# Altium schematic sheet SIMCARD.SchDoc(SIMCARD)
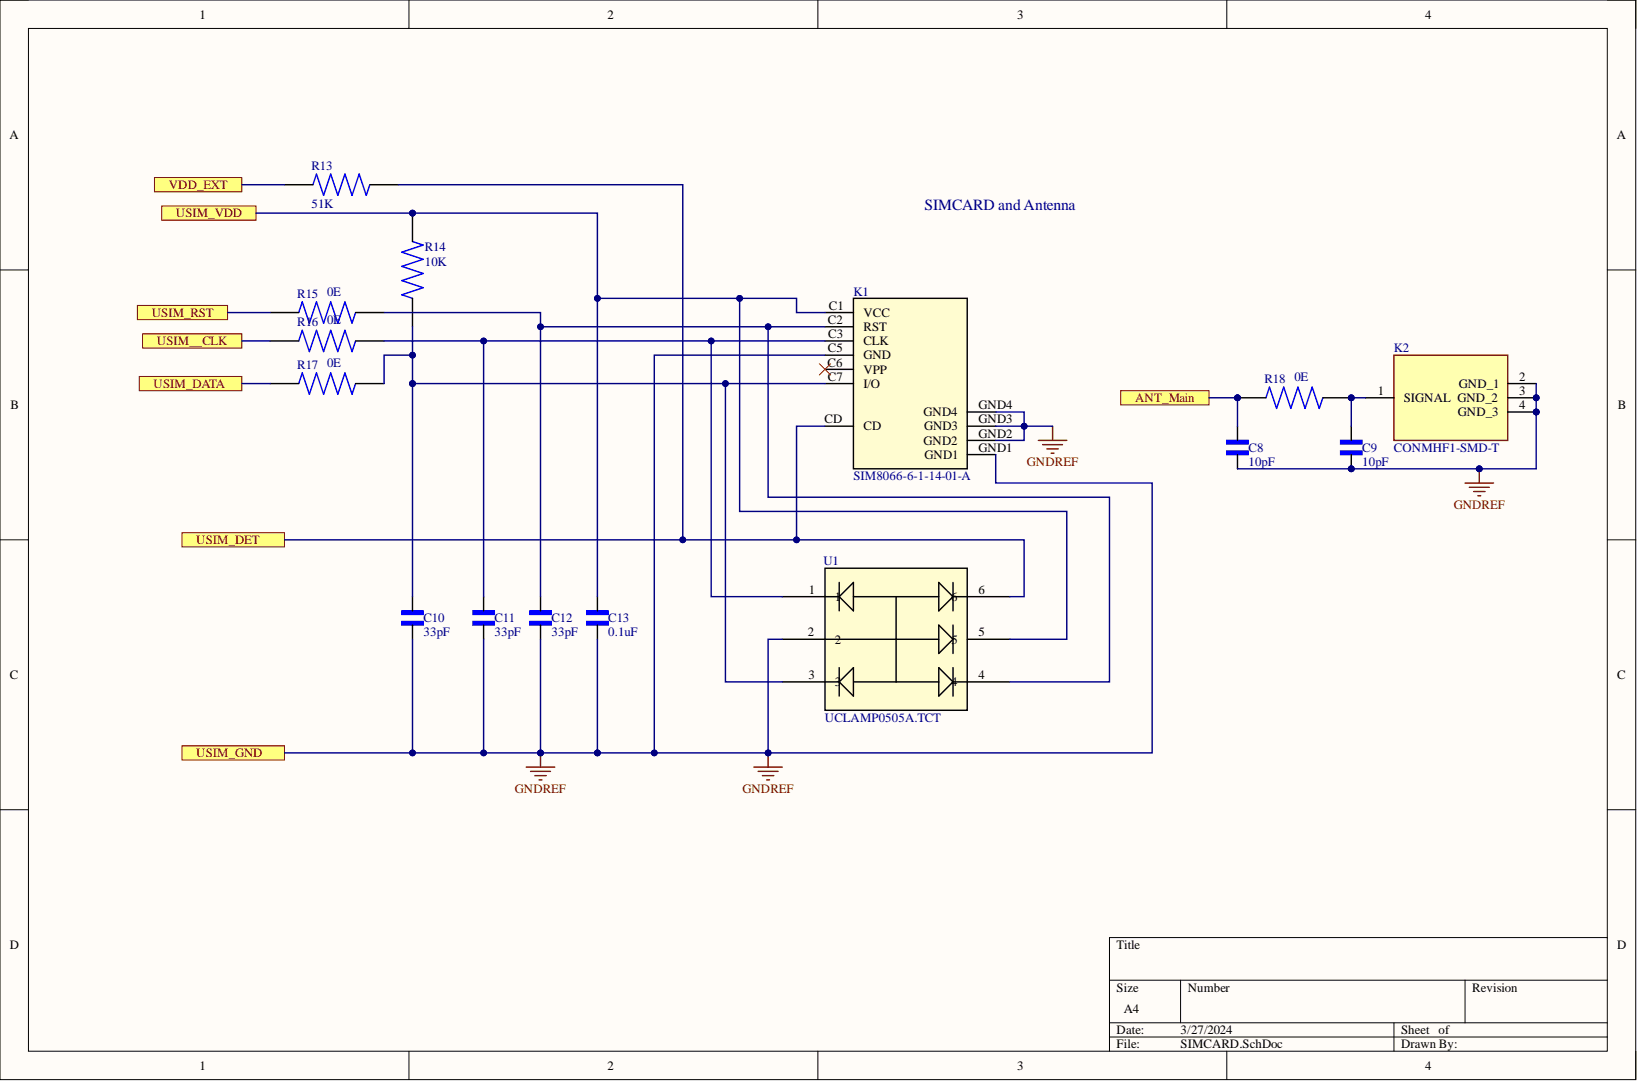
[diagram p0: SIMCARD.SchDoc]

## Parts
C8  10pF
C9  10pF
C10  33pF
C11  33pF
C12  33pF
C13  0.1uF
K1  SIM8066-6-1-14-01-A
K2  CONMHF1-SMD-T
R13  51K
R14  10K
R15  0E
R16  0E
R17  0E
R18  0E
U1  UCLAMP0505A.TCT

## Ports
ANT_Main, USIM__CLK, USIM_DATA, USIM_DET, USIM_GND, USIM_RST, USIM_VDD, VDD_EXT

## Nets
ANT_Main: C8.1 R18.1 ; port ANT_Main
GNDREF: C8.2 C9.2 C10.1 C11.1 C12.1 C13.1 K1.C5(GND) K1.GND1 K1.GND2 K1.GND3 K1.GND4 K2.2(GND_1) K2.3(GND_2) K2.4(GND_3) U1.2 ; port USIM_GND
USIM__CLK: R16.1 ; port USIM__CLK
USIM_DATA: R17.1 ; port USIM_DATA
USIM_DET: K1.CD R13.2 U1.6 ; port USIM_DET
USIM_RST: R15.1 ; port USIM_RST
USIM_VDD: C13.2 K1.C1(VCC) R14.2 U1.5 ; port USIM_VDD
VDD_EXT: R13.1 ; port VDD_EXT
NetC9_1: C9.1 K2.1(SIGNAL) R18.2
NetC10_2: C10.2 K1.C7(I/O) R14.1 R17.2 U1.3
NetC11_2: C11.2 K1.C3(CLK) R16.2 U1.1
NetC12_2: C12.2 K1.C2(RST) R15.2 U1.4

Not connected: K1 C6(VPP)

## Library data of the parts
C8 C9 = CC0603JRNPO9BN100: Capacitor: ceramic; MLCC; 10pF; 50V; C0G; Â±5%; SMD; 0603; MF: {'manufacturer': u'Yageo'}; Availability: Unavailable; Component Kind: Standard; MP: CC0603JRNPO9BN100; Package: None; Price: None
C10-C12 = GRM1885C1H330JA01D: CAPACITOR, 0603, C0G, 50V, 33PF; Capacitor Application:General Purpose; Dielectric Characteristic:C0G / NP0; Capacitance:33pF; Capacitance Tolerance:Â± 5%; Voltage Rating:50V; Capacitor Case Style:0603; Capacitor Mounting:SMD; Operating Temperature Range:-55Â°C to +125Â°C; No. of Pins:2; Reel Quantity:4000; Temperature Coefficient:0ppm/Â°C Â± 30ppm/Â°C; MF: {'manufacturer': u'Murata'}; Availability: Unavailable; Component Kind: Standard; MP: GRM1885C1H330JA01D; Package: None; Price: None
C13 = CL10B104KO8NNNC: MF: {'manufacturer': u'Samsung Electro-Mechanics'}; Availability: Unavailable; Component Kind: Standard; MP: CL10B104KO8NNNC; Package: None; Price: None
K1 = SIM8066-6-1-14-01-A: No Description Available; Mfr_Name: Global Connector Technology; Component Kind: Standard; Library Name: SIM8066-6-1-14-01-A.SchLib; Manufacturer_Part_Number: SIM8066-6-1-14-01-A; RefDes: RefDes; Type: DEV; Copyright: Copyright (C) 2024 Ultra Librarian. All rights reserved.
K2 = CONMHF1-SMD-T: RF Connectors / Coaxial Connectors MHF1 Connector Receptacle, Male Pin 50 Ohm Surface Mount Solder; Manufacturer_Name: Linx Technologies; Geometry.Height: 1.31mm; Component Kind: Standard; Datasheet Link: https://linxtechnologies.com/wp/wp-content/uploads/conmhf1-smd-t-ds.pdf; Library Name: CONMHF1-SMD-T.SchLib; Manufacturer_Part_Number: CONMHF1-SMD-T; Mouser Part Number: 712-CONMHF1-SMD-T; Mouser Price/Stock: https://www.mouser.co.uk/ProductDetail/Linx-Technologies/CONMHF1-SMD-T?qs=7D1LtPJG0i2FCBGfMy%252B%252BIw%3D%3D
R13 = RC0603FR-0751KL: RES, THICK FILM, 51K, 1%, 0.1W, 0603; MF: {'manufacturer': u'Yageo'}; Availability: Unavailable; Component Kind: Standard; MP: RC0603FR-0751KL; Package: None; Price: None
R14 = RC0603FR-0710KL: YAGEO RC0603FR-0710KL / RESISTOR 10K 1/10W 1% 0603 YAG <a href="https://pricing.snapeda.com/parts/RC0603FR-0710KL/Yageo/view-part?ref=eda">Check availability</a>; MF: {'manufacturer': u'Yageo'}; Availability: Unavailable; Component Kind: Standard; MP: RC0603FR-0710KL; Package: None; Price: None
R15-R18 = RMCF0603ZT0R00: MF: {'manufacturer': u'Stackpole Electronics'}; Availability: Unavailable; Component Kind: Standard; MP: RMCF0603ZT0R00; Package: None; Price: None
U1 = UCLAMP0505A.TCT: No Description Available; Mfr_Name: Semtech; Component Kind: Standard; Library Name: UCLAMP0505APTCT.SchLib; Manufacturer_Part_Number: UCLAMP0505A.TCT; RefDes: RefDes; Type: DEV; Copyright: Copyright (C) 2024 Ultra Librarian. All rights reserved.
